# Altium schematic sheet [11] - FPGA BANK 0, XADC and Clock.SchDoc("[11] - FPGA BANK 0, XADC and Clock")
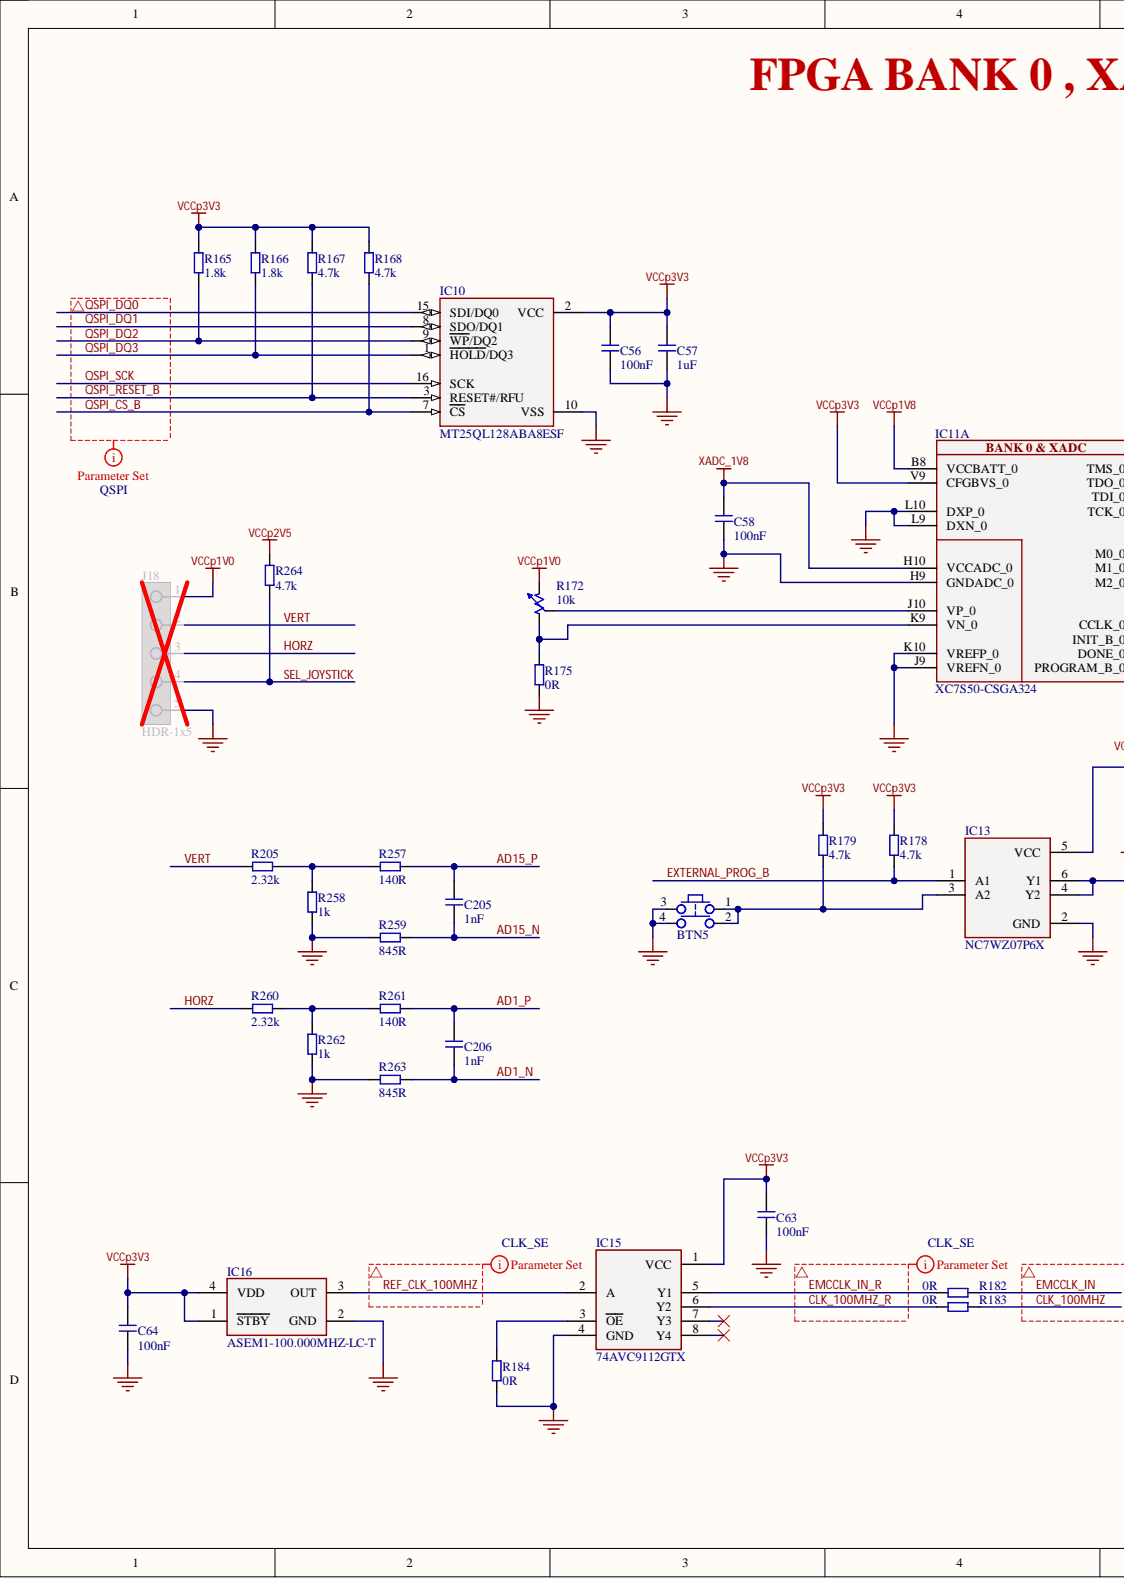
[diagram p0: [11] - FPGA BANK 0, XADC and Clock.SchDoc, left]
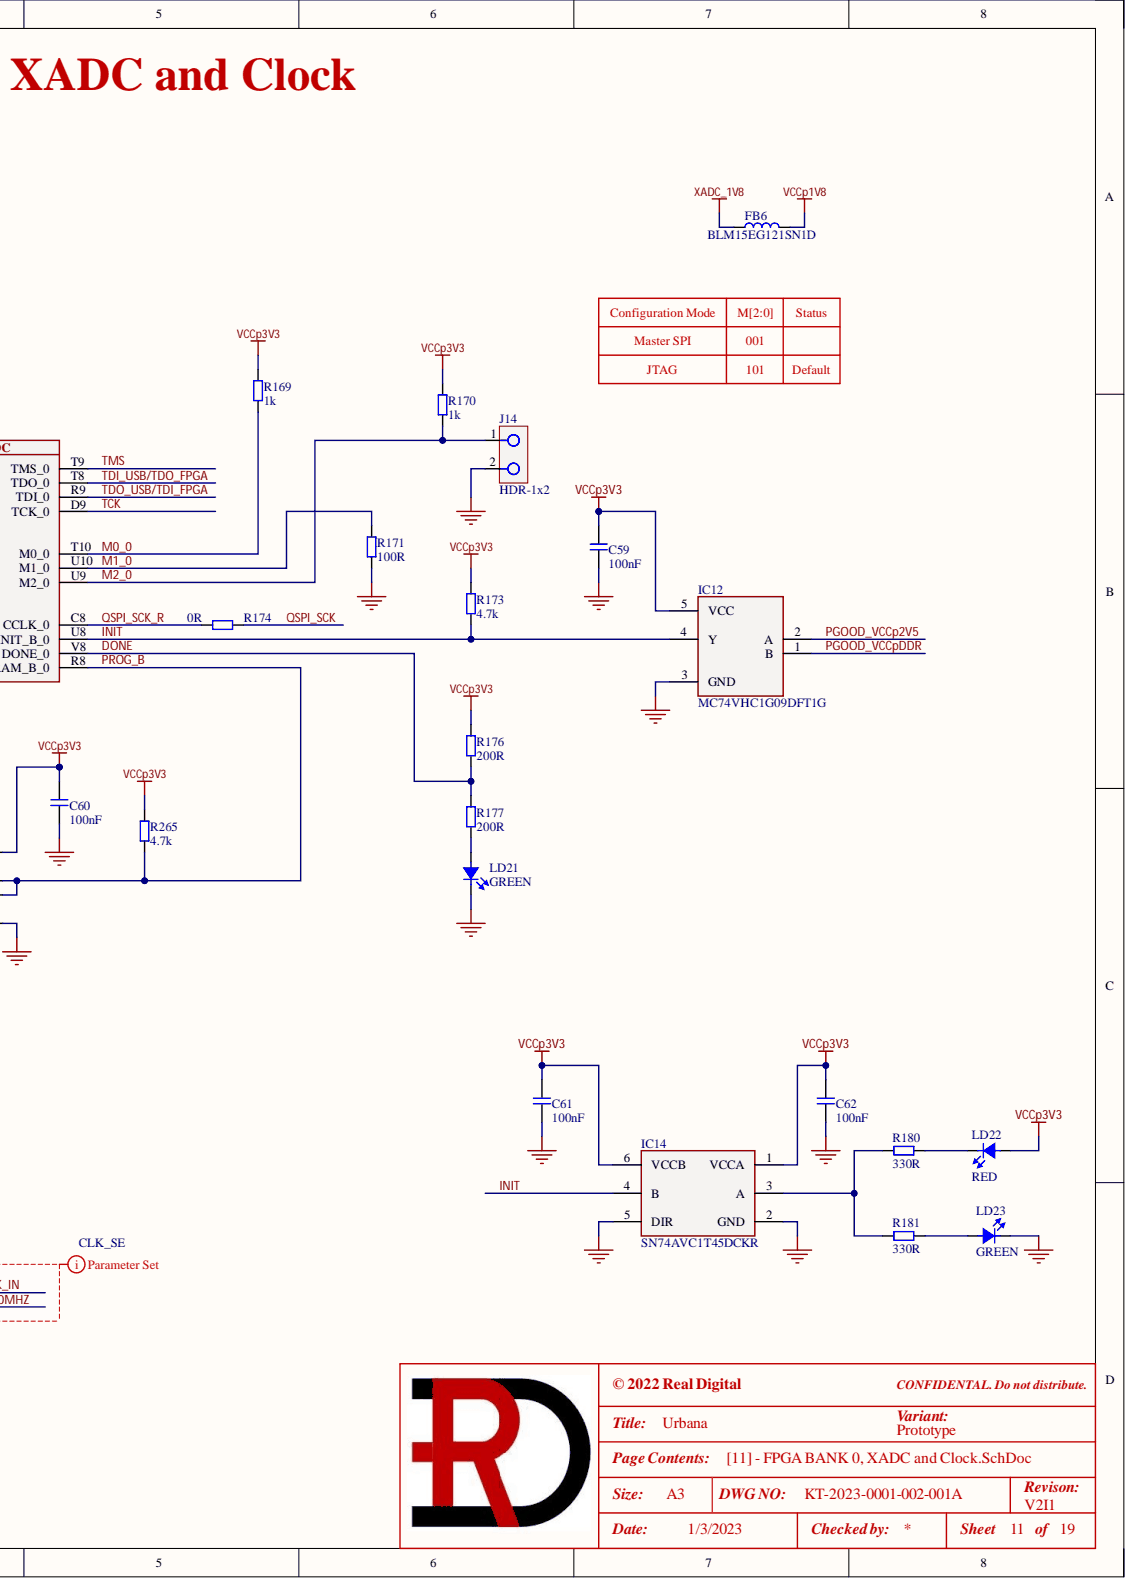
[diagram p1: [11] - FPGA BANK 0, XADC and Clock.SchDoc, right]

## Parts
BTN5  PTA-142  [PTA-142]  (value not drawn)
C56  100nF  [C0402]
C57  1uF  [C0603]
C58  100nF  [C0402]
C59  100nF  [C0402]
C60  100nF  [C0402]
C61  100nF  [C0402]
C62  100nF  [C0402]
C63  100nF  [C0402]
C64  100nF  [C0402]
C205  1nF  [C0402]
C206  1nF  [C0402]
FB6  BLM15EG121SN1D  [BLM15]
IC10  MT25QL128ABA8ESF  [SOIC-16W]
IC11  XC7S50-CSGA324  [CSG324]  (part A)
IC12  MC74VHC1G09DFT1G  [SC-88A - ONSEMI]
IC13  NC7WZ07P6X  [DCK0006A_SC70-6 - TI]
IC14  SN74AVC1T45DCKR  [DCK0006A_SC70-6 - TI]
IC15  74AVC9112GTX  [XSON8 - NEXPERIA]
IC16  ASEM1-100.000MHZ-LC-T  [DSC3.2x2.5-4]
J14  HDR-1x2  [HDR1X2]
J18  HDR-1x5  [HDR1X5 - OFF]
LD21  GREEN  [LD0603_Green]
LD22  RED  [LD0603_RED]
LD23  GREEN  [LD0603_Green]
R165  1.8k  [R0402]
R166  1.8k  [R0402]
R167  4.7k  [R0402]
R168  4.7k  [R0402]
R169  1k  [R0402]
R170  1k  [R0402]
R171  100R  [R0402]
R172  10k  [R09N]
R173  4.7k  [R0402]
R174  0R  [R0402]
R175  0R  [R0402]
R176  200R  [R0402]
R177  200R  [R0402]
R178  4.7k  [R0402]
R179  4.7k  [R0402]
R180  330R  [R0402]
R181  330R  [R0402]
R182  0R  [R0402]
R183  0R  [R0402]
R184  0R  [R0402]
R205  2.32k  [R0402]
R257  140R  [R0402]
R258  1k  [R0402]
R259  845R  [R0402]
R260  2.32k  [R0402]
R261  140R  [R0402]
R262  1k  [R0402]
R263  845R  [R0402]
R264  4.7k  [R0402]
R265  4.7k  [R0402]

## Nets
GND: BTN5.3 BTN5.4 C56.1 C57.1 C58.1 C59.1 C60.1 C61.1 C62.1 C63.1 C64.1 IC10.10(VSS) IC11.H9(GNDADC_0) IC11.J9(VREFN_0) IC11.K10(VREFP_0) IC11.L9(DXN_0) IC11.L10(DXP_0) IC12.3(GND) IC13.2(GND) IC14.2(GND) IC14.5(DIR) IC15.4(GND) IC16.2(GND) J14.2 J18.5 LD21.K LD23.K R171.1 R175.1 R184.1 R258.1 R259.1 R262.1 R263.1
VCCp1V0: J18.1 R172.3
VCCp1V8: FB6.2 IC11.B8(VCCBATT_0)
VCCp2V5: R264.2
VCCp3V3: C56.2 C57.2 C59.2 C60.2 C61.2 C62.2 C63.2 C64.2 IC10.2(VCC) IC11.V9(CFGBVS_0) IC12.5(VCC) IC13.5(VCC) IC14.1(VCCA) IC14.6(VCCB) IC15.1(VCC) IC16.1(STBY) IC16.4(VDD) LD22.A R165.2 R166.2 R167.2 R168.2 R169.2 R170.2 R173.2 R176.2 R178.2 R179.2 R265.2
XADC_1V8: C58.2 FB6.1 IC11.H10(VCCADC_0)
AD1_N: C206.1 R263.2
AD1_P: C206.2 R261.2
AD15_N: C205.1 R259.2
AD15_P: C205.2 R257.2
CLK_100MHZ: R183.1
CLK_100MHZ_R: IC15.6(Y2) R183.2
DONE: IC11.V8(DONE_0) R176.1 R177.2
EMCCLK_IN: R182.1
EMCCLK_IN_R: IC15.5(Y1) R182.2
EXTERNAL_PROG_B: IC13.1(A1) R178.1
HORZ: J18.3 R260.2
INIT: IC11.U8(INIT_B_0) IC12.4(Y) IC14.4(B) R173.1
M0_0: IC11.T10(M0_0) R169.1
M1_0: IC11.U10(M1_0) R171.2
M2_0: IC11.U9(M2_0) J14.1 R170.1
PGOOD_VCCp2V5: IC12.2(A)
PGOOD_VCCpDDR: IC12.1(B)
PROG_B: IC11.R8(PROGRAM_B_0) IC13.4(Y2) IC13.6(Y1) R265.1
QSPI_CS_B: IC10.7(CS) R168.1
QSPI_DQ0: IC10.15(SDI/DQ0)
QSPI_DQ1: IC10.8(SDO/DQ1)
QSPI_DQ2: IC10.9(WP/DQ2) R165.1
QSPI_DQ3: IC10.1(HOLD/DQ3) R166.1
QSPI_RESET_B: IC10.3(RESET#/RFU) R167.1
QSPI_SCK: IC10.16(SCK) R174.2
QSPI_SCK_R: IC11.C8(CCLK_0) R174.1
REF_CLK_100MHZ: IC15.2(A) IC16.3(OUT)
SEL_JOYSTICK: J18.4 R264.1
TCK: IC11.D9(TCK_0)
TDI_USB/TDO_FPGA: IC11.T8(TDO_0)
TDO_USB/TDI_FPGA: IC11.R9(TDI_0)
TMS: IC11.T9(TMS_0)
VERT: J18.2 R205.2
NetBTN5_1: BTN5.1 BTN5.2 IC13.3(A2) R179.1
NetIC11_J10: IC11.J10(VP_0) R172.2
NetIC11_K9: IC11.K9(VN_0) R172.1 R175.2
NetIC14_3: IC14.3(A) R180.2 R181.2
NetIC15_3: IC15.3(OE) R184.2
NetLD21_A: LD21.A R177.1
NetLD22_K: LD22.K R180.1
NetLD23_A: LD23.A R181.1
NetR205_1: R205.1 R257.1 R258.2
NetR260_1: R260.1 R261.1 R262.2

Not connected: IC15 7(Y3) 8(Y4)

## Library data of the parts
BTN5 = Tact Switch: SWITCH TACTILE SPST-NO, 4.50mm x 4.50mm; Manufacturer 1: Pacer Technology Co., Ltd; Manufacturer 2 Part Number: TSXH-2GL; .Checked_PCB: RV; C P/N: 153-0059; LoadStatus: Load; Category: Tactile Switches; Component Kind: Standard; Library Name: KT-RealDigital.IntLib; Manufacturer 1 Part Number: PTA-142; .Checked_SCH: RV; .Creator: RV
C56 C58-C64 = GRM155R71E104KE14J: CAP CER 0.1uF 25V X7R 0402; Manufacturer 1: Murata Electronics; .Checked_PCB: AC; .Creator: RV; C P/N: RD-111-0006; LoadStatus: Load; Tolerance: 10%; Category: Capacitors; Component Kind: Standard; Library Name: KT-RealDigital.IntLib; Manufacturer 1 Part Number: GRM155R71E104KE14J; Temperature Coefficient: X7R; Voltage - Rated: 25V; .Checked_SCH: AC
C57 = GCJ188R71E105KA01D: CAP CER 1uF 25V X7R 0603; Manufacturer 1: Murata Electronics; .Checked_PCB: AC; .Creator: RV; C P/N: 111-0602; LoadStatus: Load; Tolerance: 10%; Category: Capacitors; Component Kind: Standard; Library Name: KT-RealDigital.IntLib; Manufacturer 1 Part Number: GCJ188R71E105KA01D; Temperature Coefficient: X7R; Voltage - Rated: 25V; .Checked_SCH: AC
C205 C206 = GRM1555C1H102JA01D: CAP CER 1000PF 50V C0G/NP0 0402; Manufacturer 1: Murata Electronics; .Checked_PCB: RV; LoadStatus: Load; Temperature Coefficient: C0G, NP0; Tolerance: 5%; Category: Capacitors; Component Kind: Standard; Library Name: KT-RealDigital.IntLib; Manufacturer 1 Part Number: GRM1555C1H102JA01D; Voltage - Rated: 50V; .Checked_SCH: RV; .Creator: RV
FB6 = BLM15EG121SN1D: FERRITE BEAD 120 OHM 0402 1LN; Manufacturer 1: Murata Electronics; .Checked_PCB: AC; .Creator: RV; C P/N: 131-0493; LoadStatus: Load; Category: Ferrite Beads; Component Kind: Standard; Library Name: KT-RealDigital.IntLib; Manufacturer 1 Part Number: BLM15EG121SN1D; .Checked_SCH: AC
IC10 = MT25QL128ABA8ESF: IC FLASH 128M SPI 133MHZ 16SOIC; Manufacturer 1: Micron Technology Inc.; .Checked_PCB: RV; LoadStatus: Load; Category: IC; Component Kind: Standard; Library Name: KT-RealDigital.IntLib; Manufacturer 1 Part Number: MT25QL128ABA8ESF; .Checked_SCH: RV; .Creator: RV
IC11 = XC7S50-CSGA324: FPGA 210 I/O 324CSBGA; Manufacturer 1: Xilinx; .Checked_PCB: RV; C P/N: RD-126-0003-CD; LoadStatus: Load; Category: IC; Component Kind: Standard; Library Name: KT-RealDigital.IntLib; Manufacturer 1 Part Number: XC7S50-CSGA324; .Checked_SCH: RV; .Creator: RV
IC12 = MC74VHC1G09DFT1G: IC GATE AND OD 1CH 2-INP SC88A; Manufacturer 1: onsemi; .Checked_PCB: RV; LoadStatus: Load; Category: IC; Component Kind: Standard; Library Name: KT-RealDigital.IntLib; Manufacturer 1 Part Number: MC74VHC1G09DFT1G; .Checked_SCH: RV; .Creator: RV
IC13 = NC7WZ07P6X: IC BUF NON-INVERT 5.5V SC70-6; Manufacturer 1: ON Semiconductor; .Checked_PCB: RV; LoadStatus: Load; Category: IC; Component Kind: Standard; Library Name: KT-RealDigital.IntLib; Manufacturer 1 Part Number: NC7WZ07P6X; .Checked_SCH: RV; .Creator: RV
IC14 = SN74AVC1T45DCKR: IC TRNSLTR BIDIRECTIONAL SC70-6; Manufacturer 1: Texas Instruments; .Checked_PCB: RV; LoadStatus: Load; Category: IC; Component Kind: Standard; Library Name: KT-RealDigital.IntLib; Manufacturer 1 Part Number: SN74AVC1T45DCKR; .Checked_SCH: RV; .Creator: RV
IC15 = 74AVC9112GTX: Clock Fanout Buffer (Distribution) IC 1:4 8-XFDFN; Manufacturer 1: Nexperia USA Inc.; .Checked_PCB: RV; LoadStatus: Load; Category: IC; Component Kind: Standard; Library Name: KT-RealDigital.IntLib; Manufacturer 1 Part Number: 74AVC9112GTX; .Checked_SCH: RV; .Creator: RV
IC16 = ASEM1-100.000MHZ-LC-T: MEMS OSC XO 100.0000MHZ CMOS SMD; Manufacturer 1: Abracon LLC; Manufacturer 2 Part Number: SMA-100000-3BL4T1; .Checked_PCB: AC; .Creator: RV; C P/N: 126-1260; LoadStatus: Load; Category: Oscillators; Component Kind: Standard; Library Name: KT-RealDigital.IntLib; Manufacturer 1 Part Number: ASEM1-100.000MHZ-LC-T; Manufacturer 2: AKER TECHNOLOGY CO., LTD.; .Checked_SCH: AC
J14 = HDR-1x2: Header 2.54mm, 1x2; Manufacturer 1: Centronic Precision Electronic; Manufacturer 1 Part Number: H6-80-02; .Checked_PCB: AC; .Creator: RV; C P/N: 163-0028; LoadStatus: Load; Category: Header; Component Kind: Standard; Library Name: KT-RealDigital.IntLib; .Checked_SCH: AC
J18 = HDR-1x5: Header 2.54mm, 1x5; Manufacturer 1: Centronic Precision Electronic; Manufacturer 1 Part Number: H6-80-05; .Checked_PCB: AC; .Creator: RV; LoadStatus: Load; Category: Header; Component Kind: Standard; Library Name: KT-RealDigital.IntLib; .Checked_SCH: AC
LD21 LD23 = LED_GREEN: LED GREEN 0603; Manufacturer 1: Everlight Electronics CO., LTD; Manufacturer 1 Part Number: 19-21SYGC/S530-E4/TR8; .Checked_PCB: AC; .Creator: RV; C P/N: 121-0006; LoadStatus: Load; Category: Led; Component Kind: Standard; Library Name: KT-RealDigital.IntLib; .Checked_SCH: AC
LD22 = LED_RED: LED RED 0603; Manufacturer 1: EVERLIGHT ELECTRONICS CO.,LTD.; Manufacturer 1 Part Number: 19-21SURC/S530-XX/TR8; .Checked_PCB: AC; .Creator: RV; C P/N: 121-0001; LoadStatus: Load; Category: Led; Component Kind: Standard; Library Name: KT-RealDigital.IntLib; .Checked_SCH: AC
R165 R166 = RC0402JR-071K8L: RES SMD 1.8K OHM 5% 1/16W 0402; Manufacturer 1: Yageo; .Checked_PCB: AC; .Creator: RV; C P/N: 101-0946; LoadStatus: Load; Category: Resistors; Component Kind: Standard; Library Name: KT-RealDigital.IntLib; Manufacturer 1 Part Number: RC0402JR-071K8L; Tolerance: 5%; .Checked_SCH: AC
R167 R168 R173 R178 R179 R264 R265 = RC0402FR-074K7P: RES SMD 4.7K OHM 1% 1/16W 0402; Manufacturer 1: Yageo; .Checked_PCB: AC; .Creator: RV; C P/N: 101-0129; LoadStatus: Load; Category: Resistors; Component Kind: Standard; Library Name: KT-RealDigital.IntLib; Manufacturer 1 Part Number: RC0402FR-074K7P; Tolerance: 1%; .Checked_SCH: AC
R169 R170 = RC0402JR-071KP: RES SMD 1K OHM 5% 1/16W 0402; Manufacturer 1: Yageo; .Checked_PCB: AC; .Creator: RV; C P/N: 101-0050; LoadStatus: Load; Category: Resistors; Component Kind: Standard; Library Name: KT-RealDigital.IntLib; Manufacturer 1 Part Number: RC0402JR-071KP; Tolerance: 5%; .Checked_SCH: AC
R171 = RC0402JR-07100RL: RES SMD 100 OHM 5% 1/16W 0402; Manufacturer 1: Yageo; .Checked_PCB: AC; .Creator: RV; C P/N: 101-0035; LoadStatus: Load; Category: Resistors; Component Kind: Standard; Library Name: KT-RealDigital.IntLib; Manufacturer 1 Part Number: RC0402JR-07100RL; Tolerance: 5%; .Checked_SCH: AC
R172 = R09N-B10K: POTENTIOMETER 10k, R09N-B10K/10φX1.8T; Manufacturer 1: Song Huei Electric CO. LTD; .Checked_PCB: RV; C P/N: 101-0210-1; LoadStatus: Load; Category: Potentiometer; Component Kind: Standard; Library Name: KT-RealDigital.IntLib; Manufacturer 1 Part Number: R09N-B10K/10φX1.8T; .Checked_SCH: RV; .Creator: RV
R174 R175 R182-R184 = RC0402FR-070RL: RES SMD 0 OHM JUMPER 1/16W 0402; Manufacturer 1: Yageo; .Checked_PCB: AC; .Creator: RV; C P/N: RD-101-0003; LoadStatus: Load; Category: Resistors; Component Kind: Standard; Library Name: KT-RealDigital.IntLib; Manufacturer 1 Part Number: RC0402FR-070RL; Tolerance: Jumper; .Checked_SCH: AC
R176 R177 = RC0402JR-07200RL: RES SMD 200 OHM 5% 1/16W 0402; Manufacturer 1: Yageo; .Checked_PCB: AC; .Creator: RV; C P/N: 101-0045; LoadStatus: Load; Category: Resistors; Component Kind: Standard; Library Name: KT-RealDigital.IntLib; Manufacturer 1 Part Number: RC0402JR-07200RL; Tolerance: 5%; .Checked_SCH: AC
R180 R181 = RC0402JR-07330RL: RES SMD 330 OHM 5% 1/16W 0402; Manufacturer 1: Yageo; .Checked_PCB: AC; .Creator: RV; C P/N: 101-0112; LoadStatus: Load; Category: Resistors; Component Kind: Standard; Library Name: KT-RealDigital.IntLib; Manufacturer 1 Part Number: RC0402JR-07330RL; Tolerance: 5%; .Checked_SCH: AC
R205 R260 = RC0402FR-072K32L: RES 2.32K OHM 1% 1/16W 0402; Manufacturer 1: Yageo; .Checked_PCB: RV; LoadStatus: Load; Category: Resistors; Component Kind: Standard; Library Name: KT-RealDigital.IntLib; Manufacturer 1 Part Number: RC0402FR-072K32L; Tolerance: 1%; .Checked_SCH: RV; .Creator: RV
R257 R261 = RC0402FR-07140RL: RES 140 OHM 1% 1/16W 0402; Manufacturer 1: Yageo; .Checked_PCB: RV; LoadStatus: Load; Category: Resistors; Component Kind: Standard; Library Name: KT-RealDigital.IntLib; Manufacturer 1 Part Number: RC0402FR-07140RL; Tolerance: 1%; .Checked_SCH: RV; .Creator: RV
R258 R262 = RC0402FR-071KL: RES 1K OHM 1% 1/16W 0402; Manufacturer 1: Yageo; .Checked_PCB: RV; LoadStatus: Load; Category: Resistors; Component Kind: Standard; Library Name: KT-RealDigital.IntLib; Manufacturer 1 Part Number: RC0402FR-071KL; Tolerance: 1%; .Checked_SCH: RV; .Creator: RV
R259 R263 = RC0402FR-07845RL: RES 845 OHM 1% 1/16W 0402; Manufacturer 1: Yageo; .Checked_PCB: RV; LoadStatus: Load; Category: Resistors; Component Kind: Standard; Library Name: KT-RealDigital.IntLib; Manufacturer 1 Part Number: RC0402FR-07845RL; Tolerance: 1%; .Checked_SCH: RV; .Creator: RV
